# Altium schematic sheet Power Supply4.SchDoc("Power Supply4")
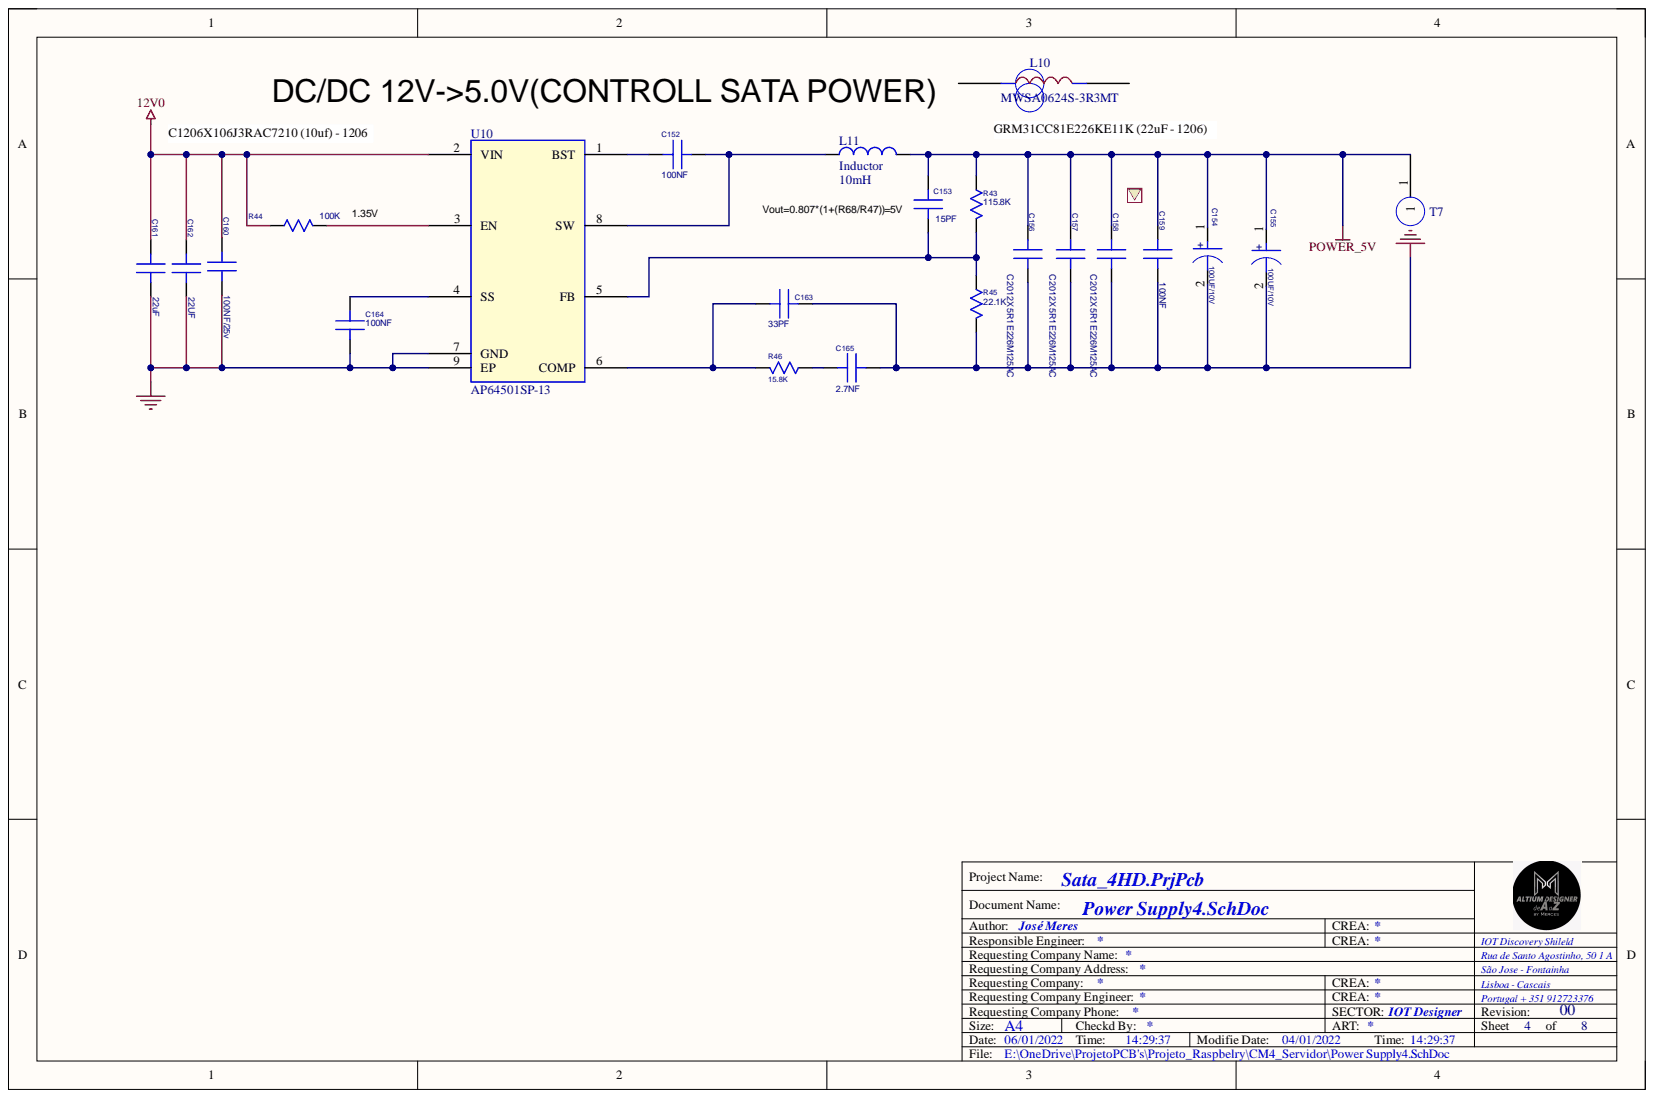
[diagram p0: Power Supply4.SchDoc]

## Parts
C152  100NF  [C0402-ROUND]
C153  15PF  [C0402-ROUND]
C154  100UF/10V  [Capacitor SMD Eletrolitic-D8.0x10]
C155  100UF/10V  [Capacitor SMD Eletrolitic-D8.0x10]
C156  C2012X5R1E226M125AC  [C0805-ROUND]
C157  C2012X5R1E226M125AC  [C0805-ROUND]
C158  C2012X5R1E226M125AC  [C0805-ROUND]
C159  100NF  [C0402-ROUND]
C160  100NF/25v  [C0805-ROUND]
C161  22uF  [C0805-ROUND]
C162  22UF  [C0805-ROUND]
C163  33PF  [C0402-ROUND]
C164  100NF  [C0402-ROUND]
C165  2.7NF  [C0402-ROUND]
L10  MWSA0624S-3R3MT  [NRS3015T2R2MNGHV]
L11  Inductor  value 10mH  [NR6045-220M]
R43  115.8K  [R0402-ROUND]
R44  100K  [R0402-ROUND]
R45  22.1K  [R0402-ROUND]
R46  15.8K  [R0402-ROUND]
T7  TEST  [TEST_40]  (value not drawn)
U10  AP64501SP-13  [SO8-EP]

## Nets
12V0: C160.1 C161.1 C162.1 R44.1 U10.2(VIN)
GND: C154.2 C155.2 C156.2 C157.2 C158.2 C159.2 C160.2 C161.2 C162.2 C163.2 C164.2 C165.2 R45.2 U10.7(GND) U10.9(EP)
POWER_5V: C153.1 C154.1 C155.1 C156.1 C157.1 C158.1 C159.1 L11.2 R43.1 T7.1
NetC152_1: C152.1 U10.1(BST)
NetC152_2: C152.2 L11.1 U10.8(SW)
NetC153_2: C153.2 R43.2 R45.1 U10.5(FB)
NetC163_1: C163.1 R46.2 U10.6(COMP)
NetC164_1: C164.1 U10.4(SS)
NetC165_1: C165.1 R46.1
NetR44_2: R44.2 U10.3(EN)

Not connected: L10 1 2

## Library data of the parts
C152 C163-C165 = C_0_Dup1: PARTS: 1; %182%%238%%182%%168%%185%%164%%215%%247%%181%%231%%209%%185%(V): 16; %195%%232%%202%%246%: SMD陶瓷电容-16V-100NF-+/-10%-X7R-0402-BT; %208%%161%%192%%224%%195%%251%%179%%198%: SMD陶瓷电容; CODE: 8070614; COMPONENT_HIGH_MAX: 0.55MM; DEVICE: RMEMK105BJ104KV_FR; LEVEL: STD; OriginalSymbolOrigin: (0,30); PCB Footprint: SC0402; PINSWAP: (A,B); Component Kind: Standard; PKG_TYPE: SC0402
C153 = C_0_Dup1: PARTS: 1; %182%%238%%182%%168%%185%%164%%215%%247%%181%%231%%209%%185%(V): 50; %195%%232%%202%%246%: SMD陶瓷电容-50V-0.015NF-+/-5%-NP0-0402; %208%%161%%192%%224%%195%%251%%179%%198%: SMD陶瓷电容; CODE: 8070544; COMPONENT_HIGH_MAX: 0.55MM; DEVICE: C0402C150J5GAC_7867; LEVEL: STD; OriginalSymbolOrigin: (0,30); PCB Footprint: SC0402; PINSWAP: (A,B); Component Kind: Standard; PKG_TYPE: SC0402
C154 C155 = CP_0: PARTS: 1; %182%%238%%182%%168%%185%%164%%215%%247%%181%%231%%209%%185%(V): 25; %195%%232%%202%%246%: 非固体SMD铝电容-25V-220UF-+/-20%-8*10.5-8.3*8.3MM-极性-105DEGC-1000H-SMD-温度敏感器件-工艺耐温250DEGC,5S; %208%%161%%192%%224%%195%%251%%179%%198%: 非固体SMD铝电容; CODE: 8120004; COMPONENT_HIGH_MAX: 10.5MM; DEVICE: EMVE250ADA221MHA0G; LEVEL: STD; OriginalSymbolOrigin: (0,30); PCB Footprint: ct7343; PKG_TYPE: SCAPC2-280-327; Component Kind: Standard
C156-C158 = C_0_Dup1: PARTS: 1; %182%%238%%182%%168%%185%%164%%215%%247%%181%%231%%209%%185%(V): 6.3; %195%%232%%202%%246%: SMD陶瓷电容-6.3V-47000NF-+/-20%-X6S-1206-供电专用; %208%%161%%192%%224%%195%%251%%179%%198%: SMD陶瓷电容; CODE: 8071639; COMPONENT_HIGH_MAX: 1.8MM; DEVICE: C3216X6S0J476MTJ00N; LEVEL: STD; OriginalSymbolOrigin: (0,30); PCB Footprint: SC0805; PINSWAP: (A,B); Component Kind: Standard; PKG_TYPE: SC0805
C159 C160 = C_0_Dup1: PARTS: 1; %182%%238%%182%%168%%185%%164%%215%%247%%181%%231%%209%%185%(V): 50; %195%%232%%202%%246%: SMD陶瓷电容-50V-100NF-+/-10%-X7R-0603; %208%%161%%192%%224%%195%%251%%179%%198%: SMD陶瓷电容; CODE: 8070627; COMPONENT_HIGH_MAX: 0.9MM; DEVICE: C1608X7R1H104KT000N; LEVEL: STD; OriginalSymbolOrigin: (0,30); PCB Footprint: SC0402; PINSWAP: (A,B); PKG_TYPE: SC0603; Component Kind: Standard
C161 C162 = C_0_Dup1: PARTS: 1; %182%%238%%182%%168%%185%%164%%215%%247%%181%%231%%209%%185%(V): 25; %195%%232%%202%%246%: SMD陶瓷电容-25V-22000NF-+/-10%-X7R-1210-所有; %208%%161%%192%%224%%195%%251%%179%%198%: SMD陶瓷电容; CODE: 8070825; COMPONENT_HIGH_MAX: 2.7MM; DEVICE: CETMK325B7226KM_T; LEVEL: STD; OriginalSymbolOrigin: (0,30); PCB Footprint: SC1206; PINSWAP: (A,B); Component Kind: Standard; PKG_TYPE: SC1206
L10 = SWPA4030S: 贴片箌率电感; PCB Footprint: SPL0707G; SIGNAL_MODEL: DEFAULT_INDUCTOR_1e+010nH_1_2; Component Kind: Standard
L11 = Inductor: Code_IEC: 1005; Code_JEITA: 0402; Component Kind: Standard; Description: Inductor; LatestRevisionDate: 17-Jul-2002; LatestRevisionNote: Re-released for DXP Platform.; PackageReference: 0402-A; Published: 8-Jun-2000; Signal Integrity: Inductor; Simulation: INDUCTOR; Library Name: Merces_Library.SchLib; Publisher: Altium Limited
R43 = R_0_Dup1: PARTS: 1; %182%%238%%182%%168%%185%%166%%194%%202%(W): 0.1; %195%%232%%202%%246%: 片式厚膜电阻器-0.1W-33200OHM-+/-1%-0603; %208%%161%%192%%224%%195%%251%%179%%198%: 片式厚膜电阻器; %215%%232%%214%%181%%212%%202%%208%%237%%198%%171%%178%%238%: +/-1%; CODE: 7090766; COMPONENT_HIGH_MAX: 0.55MM; DEVICE: RC0603FR_0733K2; LEVEL: STD; OriginalSymbolOrigin: (10,20); PCB Footprint: SR0402; PINSWAP: (A,B); Component Kind: Standard; PKG_TYPE: SR0402
R44 = R_0_Dup1: PARTS: 1; %182%%238%%182%%168%%185%%166%%194%%202%(W): 0.06; %195%%232%%202%%246%: 片式厚膜电阻器-0.0625W-100000OHM-+/-5%-0402-BT; %208%%161%%192%%224%%195%%251%%179%%198%: 片式厚膜电阻器; %215%%232%%214%%181%%212%%202%%208%%237%%198%%171%%178%%238%: +/-5%; CODE: 7090831; COMPONENT_HIGH_MAX: 0.37MM; DEVICE: RK73B1ELTP104J; LEVEL: STD; OriginalSymbolOrigin: (10,20); PCB Footprint: SR0402; PINSWAP: (A,B); Component Kind: Standard; PKG_TYPE: SR0402
R45 = R_0_Dup1: PARTS: 1; %182%%238%%182%%168%%185%%166%%194%%202%(W): 0.1; %195%%232%%202%%246%: 片式厚膜电阻器-0.1W-10700OHM-+/-1%-0603; %208%%161%%192%%224%%195%%251%%179%%198%: 片式厚膜电阻器; %215%%232%%214%%181%%212%%202%%208%%237%%198%%171%%178%%238%: +/-1%; CODE: 7090969; COMPONENT_HIGH_MAX: 0.55MM; DEVICE: RC0603FR_0710K7; LEVEL: STD; OriginalSymbolOrigin: (10,20); PCB Footprint: SR0402; PINSWAP: (A,B); PKG_TYPE: SR0603; Component Kind: Standard
R46 = R_0_Dup2: PARTS: 1; %182%%238%%182%%168%%185%%166%%194%%202%(W): 0.1; %195%%232%%202%%246%: 片式厚膜电阻器-0.1W-40200ohm-+/-1%-0603; %208%%161%%192%%224%%195%%251%%179%%198%: 片式厚膜电阻器; %215%%232%%214%%181%%212%%202%%208%%237%%198%%171%%178%%238%: +/-1%; CODE: 7090935; COMPONENT_HIGH_MAX: 0.55mm; DEVICE: RK73H1JTTD4022F; LEVEL: STD; OriginalSymbolOrigin: (10,20); PCB Footprint: SR0402; PINSWAP: (A,B); PKG_TYPE: SR0603; Component Kind: Standard
T7 = TEST: PCB Footprint: tp_40; Component Kind: Standard
U10 = AP64501SP-13: PCB Footprint: SO8EP_P1D27_W4; Component Kind: Standard; Library Name: Merces_Library.SchLib
